# Altium schematic sheet imp002-evb-modules.SchDoc
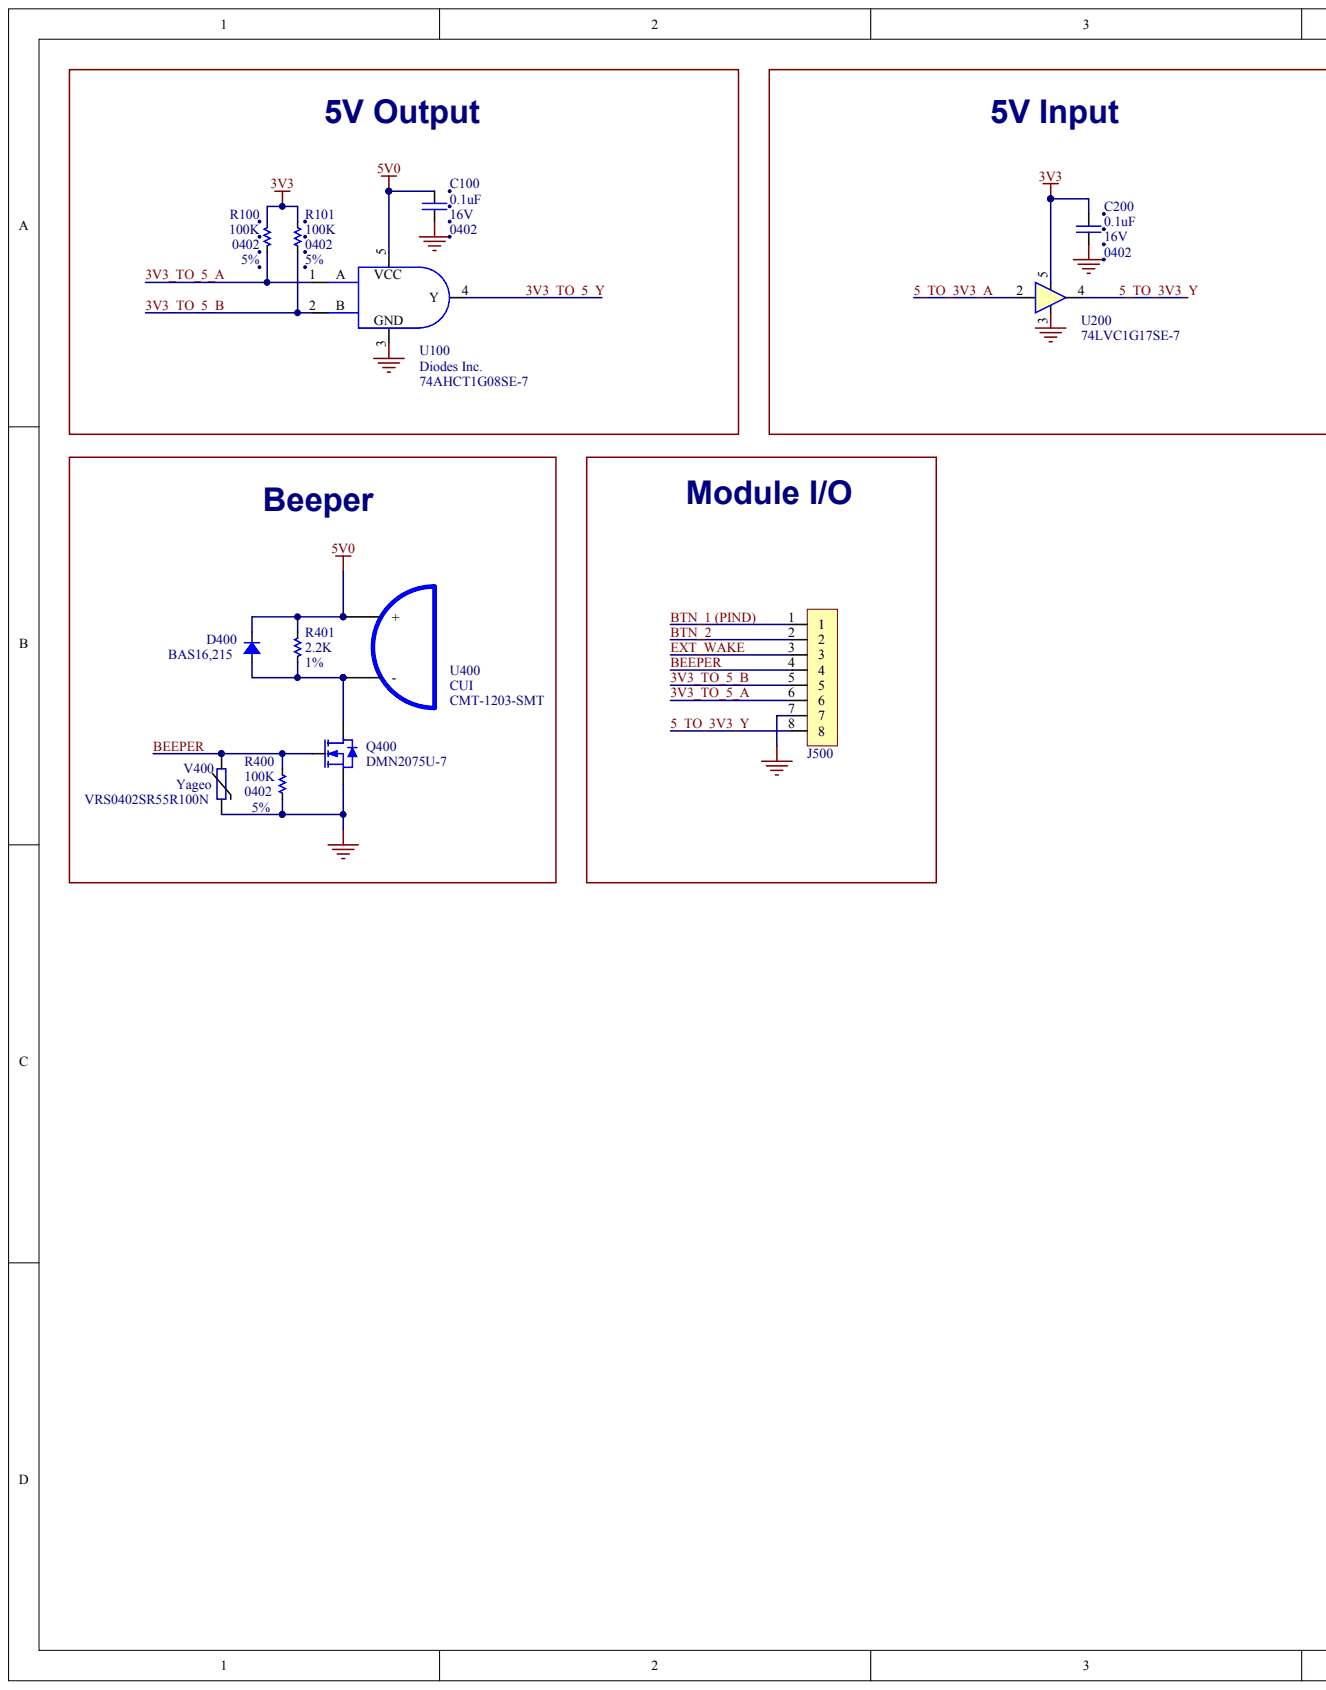
[diagram p0: imp002-evb-modules.SchDoc, left]
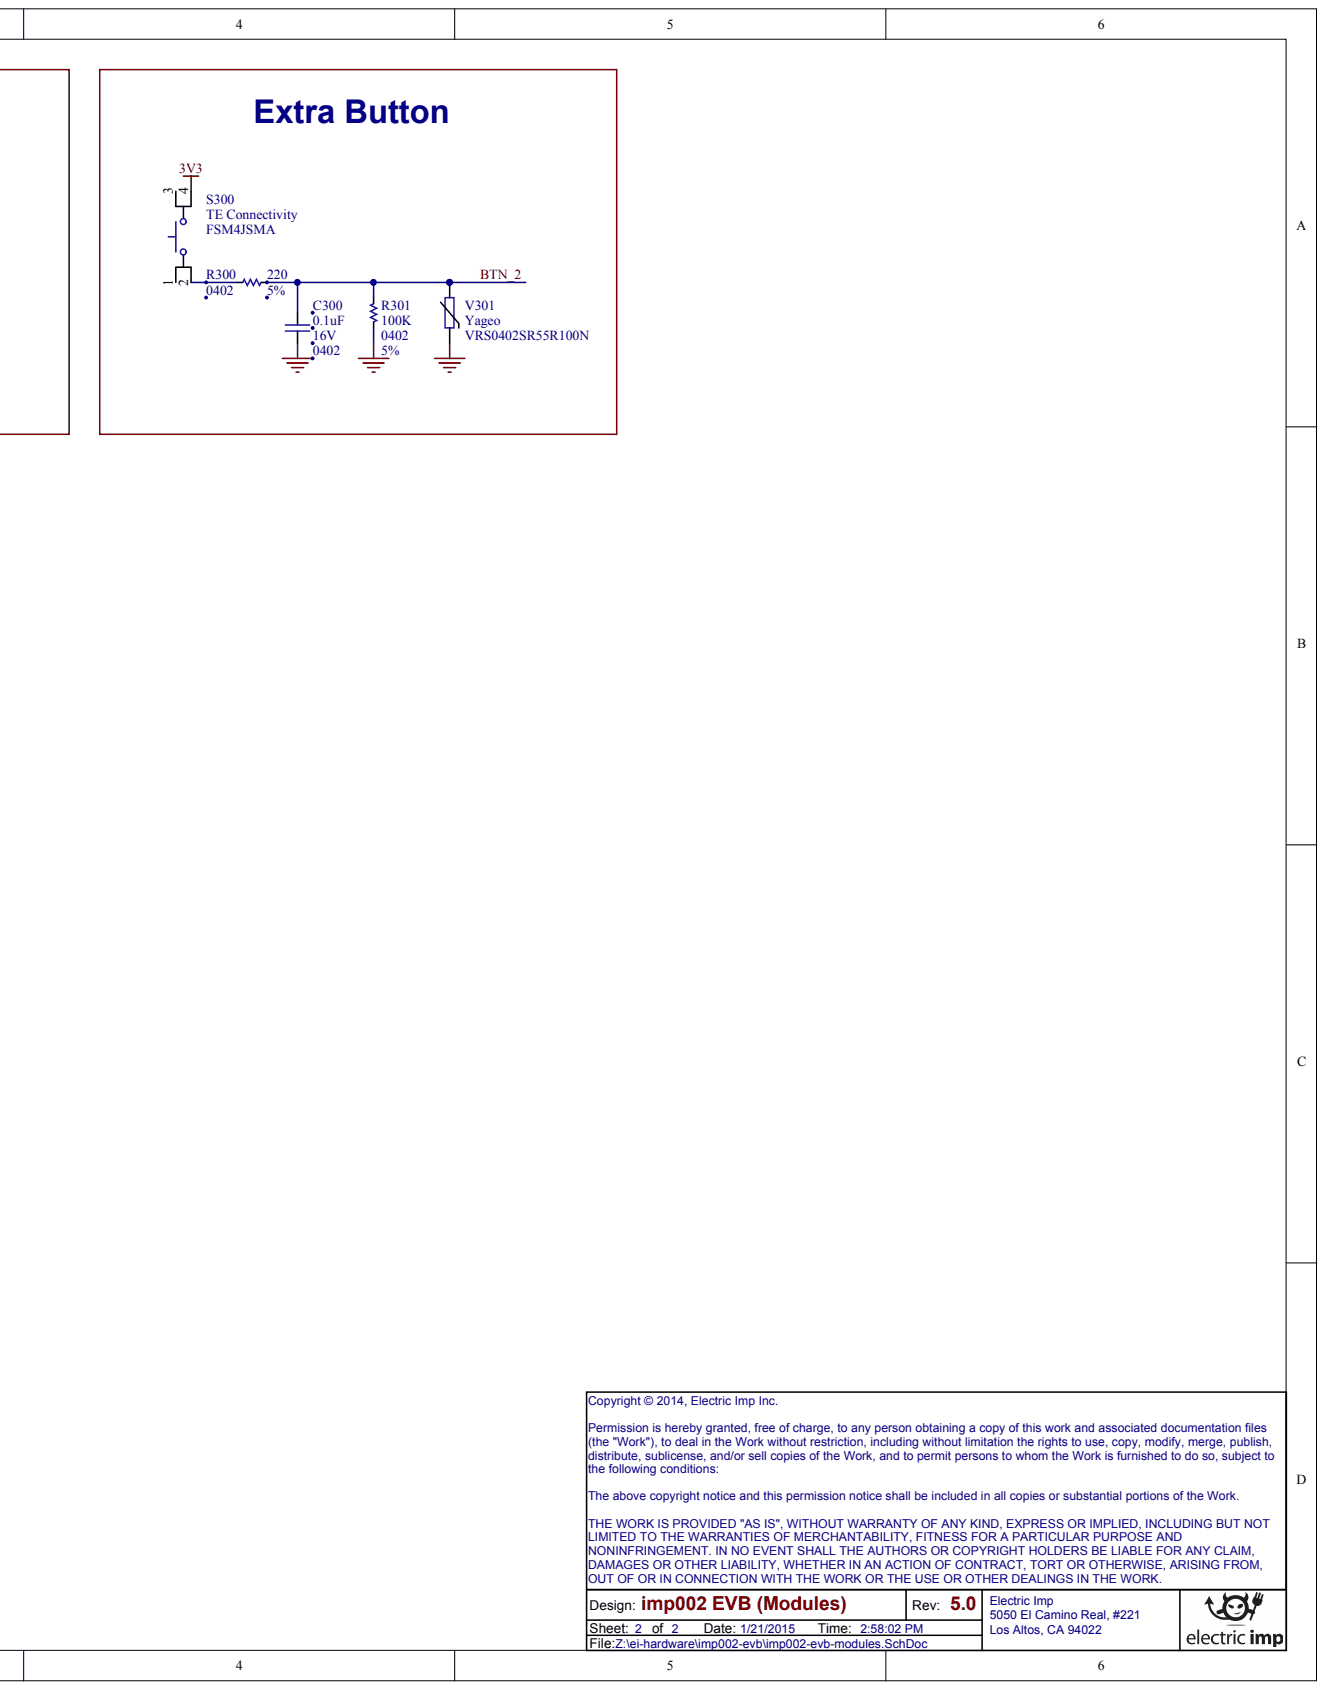
[diagram p1: imp002-evb-modules.SchDoc, right]

## Parts
C100  value 0.1uF  Voltage: 16V  Package: 0402  (hidden comment: Ceramic Capacitor)
C200  value 0.1uF  Voltage: 16V  Package: 0402  (hidden comment: Ceramic Capacitor)
C300  value 0.1uF  Voltage: 16V  Package: 0402  (hidden comment: Ceramic Capacitor)
D400  Manufacturer Part Number: BAS16,215  (hidden comment: Diode)
J500  Header  (value not drawn)
Q400  Manufacturer Part Number: DMN2075U-7  (hidden comment: N-Channel MOSFET)
R100  value 100K  Package: 0402  Tolerance: 5%  (hidden comment: Resistor)
R101  value 100K  Package: 0402  Tolerance: 5%  (hidden comment: Resistor)
R300  value 220  Package: 0402  Tolerance: 5%  (hidden comment: Resistor)
R301  value 100K  Package: 0402  Tolerance: 5%  (hidden comment: Resistor)
R400  value 100K  Package: 0402  Tolerance: 5%  (hidden comment: Resistor)
R401  value 2.2K  Tolerance: 1%  (hidden comment: Resistor)
S300  Manufacturer: TE Connectivity  Manufacturer Part Number: FSM4JSMA  (hidden comment: Push Button)
U100  Manufacturer: Diodes Inc.  Manufacturer Part Number: 74AHCT1G08SE-7  (hidden comment: AND)
U200  Manufacturer Part Number: 74LVC1G17SE-7  (hidden comment: BUFFER)
U400  Manufacturer: CUI  Manufacturer Part Number: CMT-1203-SMT  (hidden comment: BZR00001)
V301  Manufacturer: Yageo  Manufacturer Part Number: VRS0402SR55R100N  (hidden comment: VARISTOR)
V400  Manufacturer: Yageo  Manufacturer Part Number: VRS0402SR55R100N  (hidden comment: VARISTOR)

## Nets
3V3: C200.2 R100.1 R101.1 S300.4 U200.5
5V0: C100.2 D400.K R401.2 U100.5 U400.1
GND: C100.1 C200.1 C300.1 J500.7 Q400.2 R301.1 R400.1 U100.3 U200.3 V301.2 V400.2
3V3_TO_5_A: J500.6 R100.2 U100.1(A)
3V3_TO_5_B: J500.5 R101.2 U100.2(B)
3V3_TO_5_Y: U100.4(Y)
5_TO_3V3_A: U200.2
5_TO_3V3_Y: J500.8 U200.4
BEEPER: J500.4 Q400.1 R400.2 V400.1
BTN_1 (PIND): J500.1
BTN_2: C300.2 J500.2 R300.2 R301.2 V301.1
EXT_WAKE: J500.3
NetD400_A: D400.A Q400.3 R401.1 U400.2
NetR300_1: R300.1 S300.2

Not connected: S300 1 3

## Library data of the parts
C100 C200 C300 = (no symbol name): Part Number: CAPC00025; Manufacturer: Samsung; Manufacturer Part Number: CL05B104KO5NNNC; Tolerance: 10%; Dielectric: X7R; Manufacturer 2: Taiyo Yuden; Manufacturer Part Number 2: EMK105B7104KV-F; Supplier 1: Digi-Key; Supplier Part Number 1: 1276-1001-1-ND; Supplier Price 1: 0.0039; Supplier 2: Digi-Key; Supplier Part Number 2: 587-1451-1-ND; Supplier Price 2: 0.0045; Stock Quantity: 1000; Stock Date: 3/12/2013
D400 = (no symbol name): Part Number: DIODE00003; Manufacturer: NXP; Voltage - Forward (Vf) (Max) @ If: 1.25V @150mA; Current - Forward DC: 215mA; Current - Reverse Leakage @ Vr: 0.5uA @ 80V; Continuous Reverse Voltage: 100V; Power - Max: 250mW; Package: SOT23; Supplier 1: Digi-Key; Supplier Part Number 1: 568-1596-1-ND; Supplier Price 1: 0.02688; Stock Quantity: 42; Stock Date: 3/4/2013; ComponentLink1URL: http://www.nxp.com/documents/data_sheet/BAS16_SER.pdf
J500 = (no symbol name): Part Number: HDR00012; Manufacturer: 3M; Manufacturer Part Number: 960108-6202-AR; Gender: Female; Connector Type: Socket; Number of Columns: 8; Pitch: 2.54mm; Number of Rows: 1; Number of Positions Loaded: 8; Mounting Type: Through Hole; Orientation: Vertical; Contact Finish: Gold Flash; Manufacturer 2: 4UCON; Manufacturer Part Number 2: 19743 1x08PIN; Supplier 1: Digi-Key; Supplier Part Number 1: 3M9518-ND; Supplier Price 1: 0.765; Supplier 2: 4UCON; Supplier Part Number 2: 19743 1x08PIN; Supplier Price 2: 0.0402
Q400 = (no symbol name): Part Number: NFET00004; Manufacturer: Diodes Incorporated; Drain to Source Voltage (Vdss): 20V; Current - Continuous Drain (Id) @ 25° C: 4.2A; Rds On (Max) @ Id, Vgs: 45 mOhm @ 3.1A, 2.5V; Vgs(th) (Max) @ Id: 1.0V @ 250uA; Power - Max: 800mW; Package: SOT23; Supplier 1: Digi-Key; Supplier Part Number 1: DMN2075U-7DICT-ND; Supplier Price 1: 0.08295; Stock Quantity: 41; Stock Date: 3/5/2013; ComponentLink1URL: http://www.diodes.com/datasheets/ds31837.pdf
R100 R101 R301 = (no symbol name): Part Number: RES00001; Manufacturer: Samsung; Manufacturer Part Number: RC1005J104CS; Power: 0.063W; Manufacturer 2: ROHM; Manufacturer Part Number 2: MCR01MRTJ104; Supplier 1: Digi-Key; Supplier Part Number 1: 1276-4424-1-ND; Supplier Price 1: 0.0023; Supplier 2: Digi-Key; Supplier Part Number 2: HM100KCECT-ND; Supplier Price 2: 0.00233; Stock Quantity: 2100; Stock Date: 4/5/2013
R300 = (no symbol name): Part Number: RES00011; Manufacturer: Yageo; Manufacturer Part Number: RC0402JR-07220RL; Power: 0.063W; Manufacturer 2: Panasonic; Manufacturer Part Number 2: ERJ-2GEJ221X; Supplier 1: Digi-Key; Supplier Part Number 1: 311-220JRCT-ND; Supplier Price 1: 0.00237; Supplier 2: Digi-Key; Supplier Part Number 2: P220JCT-ND; Supplier Price 2: 0.0048
R400 = (no symbol name): Part Number: RES00001; Manufacturer: Stackpole; Manufacturer Part Number: RMCF0402JT100K; Power: 0.063W; Manufacturer 2: ROHM; Manufacturer Part Number 2: MCR01MRTJ104; Supplier 1: Digi-Key; Supplier Part Number 1: RMCF0402JT100KCT-ND; Supplier Price 1: 0.00256; Supplier 2: Digi-Key; Supplier Part Number 2: RHM100KCECT-ND; Supplier Price 2: 0.00352
R401 = (no symbol name): Part Number: RES00037; Manufacturer: Stackpole; Manufacturer Part Number: RMCF0402FT2K20; Power: 0.063W; Package: 0402; Manufacturer 2: Rohm; Manufacturer Part Number 2: MCR01MRTF2201; Supplier 1: Digi-Key; Supplier Part Number 1: RMCF0402FT2K20CT-ND; Supplier Price 1: 0.00384; Supplier 2: Digi-Key; Supplier Part Number 2: RHM2.20KCDCT-ND; Supplier Price 2: 0.0048; Stock Quantity: 1000; Stock Date: 4/2/2013
S300 = (no symbol name): Part Number: BTN00001; Supplier 1: Digi-Key; Supplier Part Number 1: 450-1129-ND; Supplier Price 1: 0.0917; ComponentLink1URL: http://www.digikey.com/product-detail/en/FSM4JSMATR/450-1759-1-ND/2271638
U100 = (no symbol name): Part Number: IC00116; Manufacturer 2: NXP; Manufacturer Part Number 2: 74AHCT1G08GW,125; Supplier 1: Digi-Key; Supplier Part Number 1: 74AHCT1G08SE-7DICT-ND; Supplier Price 1: 0.04886; Supplier 2: Digi-Key; Supplier Part Number 2: 568-4463-1-ND; Supplier Price 2: 0.07022; Stock Quantity: 0; ComponentLink1URL: http://www.digikey.com/product-detail/en/74AHCT1G08SE-7/74AHCT1G08SE-7DICT-ND/2712017
U200 = (no symbol name): Manufacturer: Diodes Inc.; Part Number: IC00164; Supplier 1: Digi-Key; Supplier Part Number 1: 74LVC1G17SE-7CT-ND; Supplier Price 1: 0.05129; ComponentLink1URL: http://www.digikey.com/product-detail/en/74LVC1G17SE-7/74LVC1G17SE-7CT-ND/3829456
V301 V400 = (no symbol name): Part Number: VAR00001; Max VDC: 5.5V; Varistor Voltage: 10V; Imax: 10A; Supplier 1: Digi-Key; Supplier Part Number 1: 311-1515-1-ND; Supplier Price 1: 0.00529; ComponentLink1URL: http://www.digikey.com/product-detail/en/VRS0402SR55R100N/311-1515-1-ND/2833821
U400 = (no symbol name): Part Number: BZR00001; Supplier Price 1: 1.02543; ComponentLink1URL: http://www.digikey.com/product-detail/en/CMT-1203-SMT/102-2197-2-ND/2364638; Supplier 1: Digi-Key; Supplier Part Number 1: 102-2197-2-ND
